# Altium schematic sheet 1_Interface.SchDoc
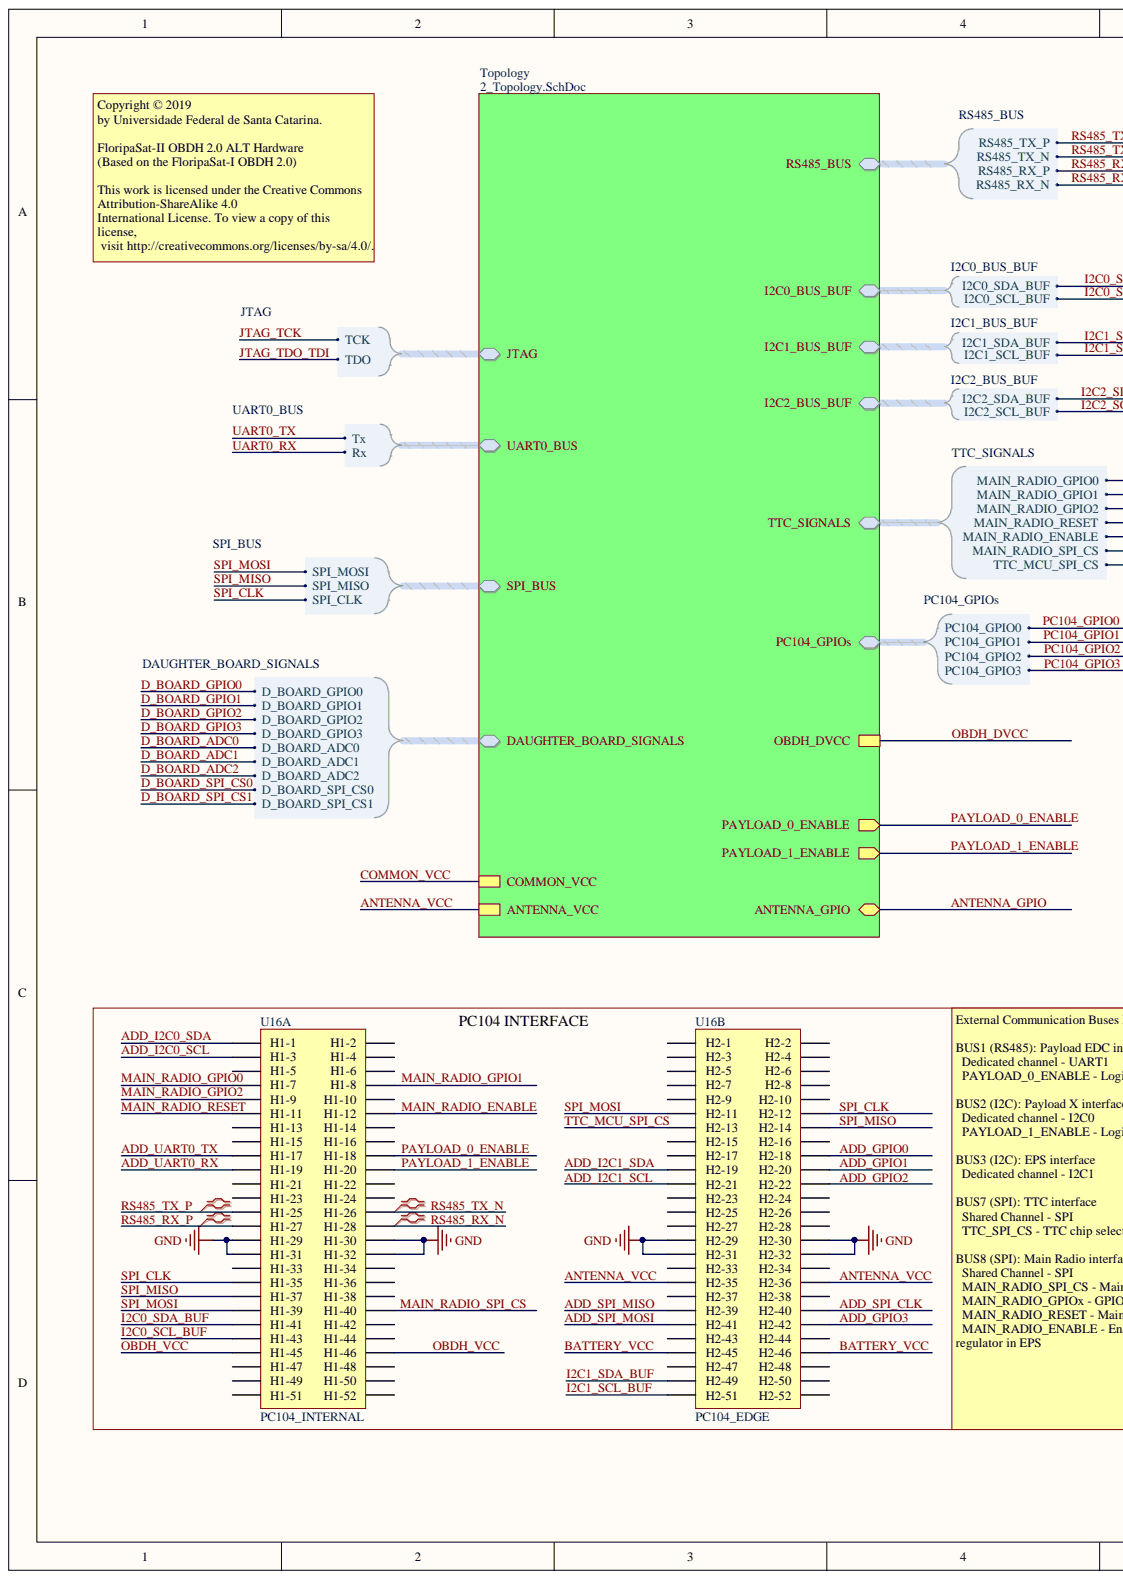
[diagram p0: 1_Interface.SchDoc, left]
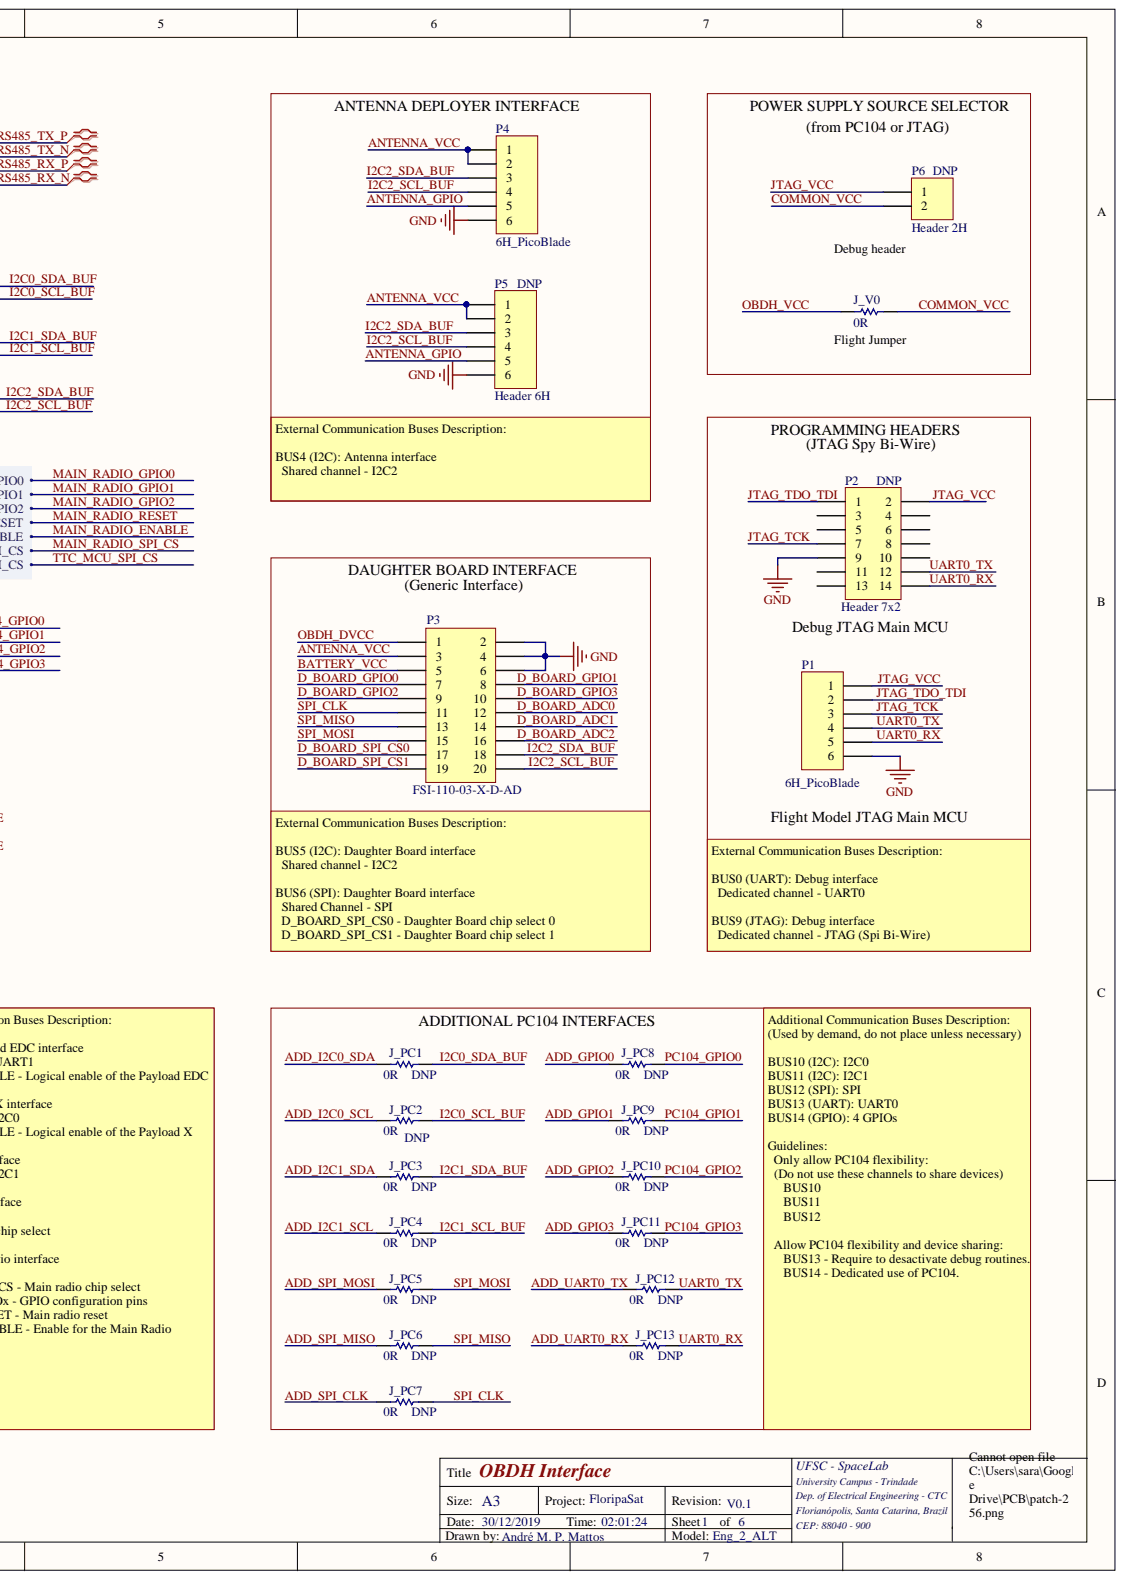
[diagram p1: 1_Interface.SchDoc, right]

## Parts
J_PC1  0R  [0805]
J_PC2  0R  [0805]
J_PC3  0R  [0805]
J_PC4  0R  [0805]
J_PC5  0R  [0805]
J_PC6  0R  [0805]
J_PC7  0R  [0805]
J_PC8  0R  [0805]
J_PC9  0R  [0805]
J_PC10  0R  [0805]
J_PC11  0R  [0805]
J_PC12  0R  [0805]
J_PC13  0R  [0805]
J_V0  0R  [0805]
P1  6H_PicoBlade  [HDR6 PicoBlade]
P2  Header 7x2  [HDR2X7]
P3  FSI-110-03-X-D-AD  [*FSI-110-03-X-D-AD]
P4  6H_PicoBlade  [HDR6 PicoBlade]
P5  Header 6H  [HDR1X6H]
P6  Header 2H  [HDR 1X2]
U16  PC104_INTERNAL  [PC104]  (parts A,B)

## Nets
GND: P1.6 P2.9 P3.2 P3.4 P3.6 P4.6 P5.6 U16.H1-29 U16.H1-30 U16.H1-31 U16.H1-32 U16.H2-29 U16.H2-30 U16.H2-31 U16.H2-32
ADD_GPIO0: J_PC8.1 U16.H2-18
ADD_GPIO1: J_PC9.1 U16.H2-20
ADD_GPIO2: J_PC10.1 U16.H2-22
ADD_GPIO3: J_PC11.1 U16.H2-42
ADD_I2C0_SCL: J_PC2.1 U16.H1-3
ADD_I2C0_SDA: J_PC1.1 U16.H1-1
ADD_I2C1_SCL: J_PC4.1 U16.H2-21
ADD_I2C1_SDA: J_PC3.1 U16.H2-19
ADD_SPI_CLK: J_PC7.1 U16.H2-40
ADD_SPI_MISO: J_PC6.1 U16.H2-39
ADD_SPI_MOSI: J_PC5.1 U16.H2-41
ADD_UART0_RX: J_PC13.1 U16.H1-19
ADD_UART0_TX: J_PC12.1 U16.H1-17
ANTENNA_GPIO: P4.5 P5.5
ANTENNA_VCC: P3.3 P4.1 P4.2 P5.1 P5.2 U16.H2-35 U16.H2-36
BATTERY_VCC: P3.5 U16.H2-45 U16.H2-46
COMMON_VCC: J_V0.2 P6.2
D_BOARD_GPIO0: P3.7
D_BOARD_GPIO2: P3.9
D_BOARD_SPI_CS0: P3.17
D_BOARD_SPI_CS1: P3.19
I2C0_SCL_BUF: J_PC2.2 U16.H1-43
I2C0_SDA_BUF: J_PC1.2 U16.H1-41
I2C1_SCL_BUF: J_PC4.2 U16.H2-51
I2C1_SDA_BUF: J_PC3.2 U16.H2-49
I2C2_SCL_BUF: P3.20 P4.4 P5.4
I2C2_SDA_BUF: P3.18 P4.3 P5.3
JTAG_TCK: P1.3 P2.7
JTAG_TDO_TDI: P1.2 P2.1
JTAG_VCC: P1.1 P2.2 P6.1
MAIN_RADIO_ENABLE: U16.H1-12
MAIN_RADIO_GPIO0: U16.H1-7
MAIN_RADIO_GPIO1: U16.H1-8
MAIN_RADIO_GPIO2: U16.H1-9
MAIN_RADIO_RESET: U16.H1-11
MAIN_RADIO_SPI_CS: U16.H1-40
OBDH_DVCC: P3.1
OBDH_VCC: J_V0.1 U16.H1-45 U16.H1-46
PAYLOAD_0_ENABLE: U16.H1-18
PAYLOAD_1_ENABLE: U16.H1-20
PC104_GPIO0: J_PC8.2
PC104_GPIO1: J_PC9.2
PC104_GPIO2: J_PC10.2
PC104_GPIO3: J_PC11.2
RS485_RX_N: U16.H1-28
RS485_RX_P: U16.H1-27
RS485_TX_N: U16.H1-26
RS485_TX_P: U16.H1-25
SPI_CLK: J_PC7.2 P3.11 U16.H1-35 U16.H2-12
SPI_MISO: J_PC6.2 P3.13 U16.H1-37 U16.H2-14
SPI_MOSI: J_PC5.2 P3.15 U16.H1-39 U16.H2-11
TTC_MCU_SPI_CS: U16.H2-13
UART0_RX: J_PC13.2 P1.5 P2.14
UART0_TX: J_PC12.2 P1.4 P2.12
NetP2_3: P2.3
NetP2_4: P2.4
NetP2_5: P2.5
NetP2_6: P2.6
NetP2_8: P2.8
NetP2_10: P2.10
NetP2_11: P2.11
NetP2_13: P2.13
NetP3_8: P3.8
NetP3_10: P3.10
NetP3_12: P3.12
NetP3_14: P3.14
NetP3_16: P3.16
NetU16_H1-2: U16.H1-2
NetU16_H1-4: U16.H1-4
NetU16_H1-5: U16.H1-5
NetU16_H1-6: U16.H1-6
NetU16_H1-10: U16.H1-10
NetU16_H1-13: U16.H1-13
NetU16_H1-14: U16.H1-14
NetU16_H1-15: U16.H1-15
NetU16_H1-16: U16.H1-16
NetU16_H1-21: U16.H1-21
NetU16_H1-22: U16.H1-22
NetU16_H1-23: U16.H1-23
NetU16_H1-24: U16.H1-24
NetU16_H1-33: U16.H1-33
NetU16_H1-34: U16.H1-34
NetU16_H1-36: U16.H1-36
NetU16_H1-38: U16.H1-38
NetU16_H1-42: U16.H1-42
NetU16_H1-44: U16.H1-44
NetU16_H1-47: U16.H1-47
NetU16_H1-48: U16.H1-48
NetU16_H1-49: U16.H1-49
NetU16_H1-50: U16.H1-50
NetU16_H1-51: U16.H1-51
NetU16_H1-52: U16.H1-52
NetU16_H2-1: U16.H2-1
NetU16_H2-2: U16.H2-2
NetU16_H2-3: U16.H2-3
NetU16_H2-4: U16.H2-4
NetU16_H2-5: U16.H2-5
NetU16_H2-6: U16.H2-6
NetU16_H2-7: U16.H2-7
NetU16_H2-8: U16.H2-8
NetU16_H2-9: U16.H2-9
NetU16_H2-10: U16.H2-10
NetU16_H2-15: U16.H2-15
NetU16_H2-16: U16.H2-16
NetU16_H2-17: U16.H2-17
NetU16_H2-23: U16.H2-23
NetU16_H2-24: U16.H2-24
NetU16_H2-25: U16.H2-25
NetU16_H2-26: U16.H2-26
NetU16_H2-27: U16.H2-27
NetU16_H2-28: U16.H2-28
NetU16_H2-33: U16.H2-33
NetU16_H2-34: U16.H2-34
NetU16_H2-37: U16.H2-37
NetU16_H2-38: U16.H2-38
NetU16_H2-43: U16.H2-43
NetU16_H2-44: U16.H2-44
NetU16_H2-47: U16.H2-47
NetU16_H2-48: U16.H2-48
NetU16_H2-50: U16.H2-50
NetU16_H2-52: U16.H2-52
D_BOARD_ADC0:
D_BOARD_ADC1:
D_BOARD_ADC2:
D_BOARD_GPIO1:
D_BOARD_GPIO3:

## Library data of the parts
J_PC1-J_PC13 J_V0 = R0R_JUMPER_1/16W_0805: RES 0R OHM 1/16W JUMPER 0805; Partnumber: CRCW08050000Z0EA; Component Kind: Standard; NCM: 8533.21.20; Library Name: OBDH_Eng_Model_2_ALT.SCHLIB
P1 P4 = Header 6H_PicoBlade: Header, 6-Pin, Right Angle; Partnumber: 53398-0671; Component Kind: Standard; LatestRevisionDate: 17-Jul-2002; LatestRevisionNote: Re-released for DXP Platform.; Signal Integrity: Connector; Library Name: OBDH_Eng_Model_2_ALT.SCHLIB; Publisher: Altium Limited
P2 = Header 7X2: Header, 7-Pin, Dual row; Component Kind: Standard; LatestRevisionDate: 17-Jul-2002; LatestRevisionNote: Re-released for DXP Platform.; Library Name: Miscellaneous Connectors.IntLib; Signal Integrity: Connector; Publisher: Altium Limited
P5 = Header 6H: Header, 6-Pin, Right Angle; Component Kind: Standard; LatestRevisionDate: 17-Jul-2002; LatestRevisionNote: Re-released for DXP Platform.; Library Name: Miscellaneous Connectors.IntLib; Signal Integrity: Connector; Publisher: Altium Limited
P6 = Header 2: Header, 2-Pin; PartNumber: 826646-2; Component Kind: Standard; LatestRevisionDate: 17-Jul-2002; LatestRevisionNote: Re-released for DXP Platform.; Signal Integrity: Connector; Library Name: OBDH_Eng_Model_2_ALT.SCHLIB; Publisher: Altium Limited
U16 = PC104: Partnumber: ESQ-126-39-G-D; Component Kind: Standard; Description: PC104; Library Name: OBDH_Eng_Model_2_ALT.SCHLIB
P3 = FSI-110-03-X-D-AD: Component Kind: Standard; Library Name: OBDH_Eng_Model_2_ALT.SCHLIB
